# Altium schematic sheet v2.SchDoc
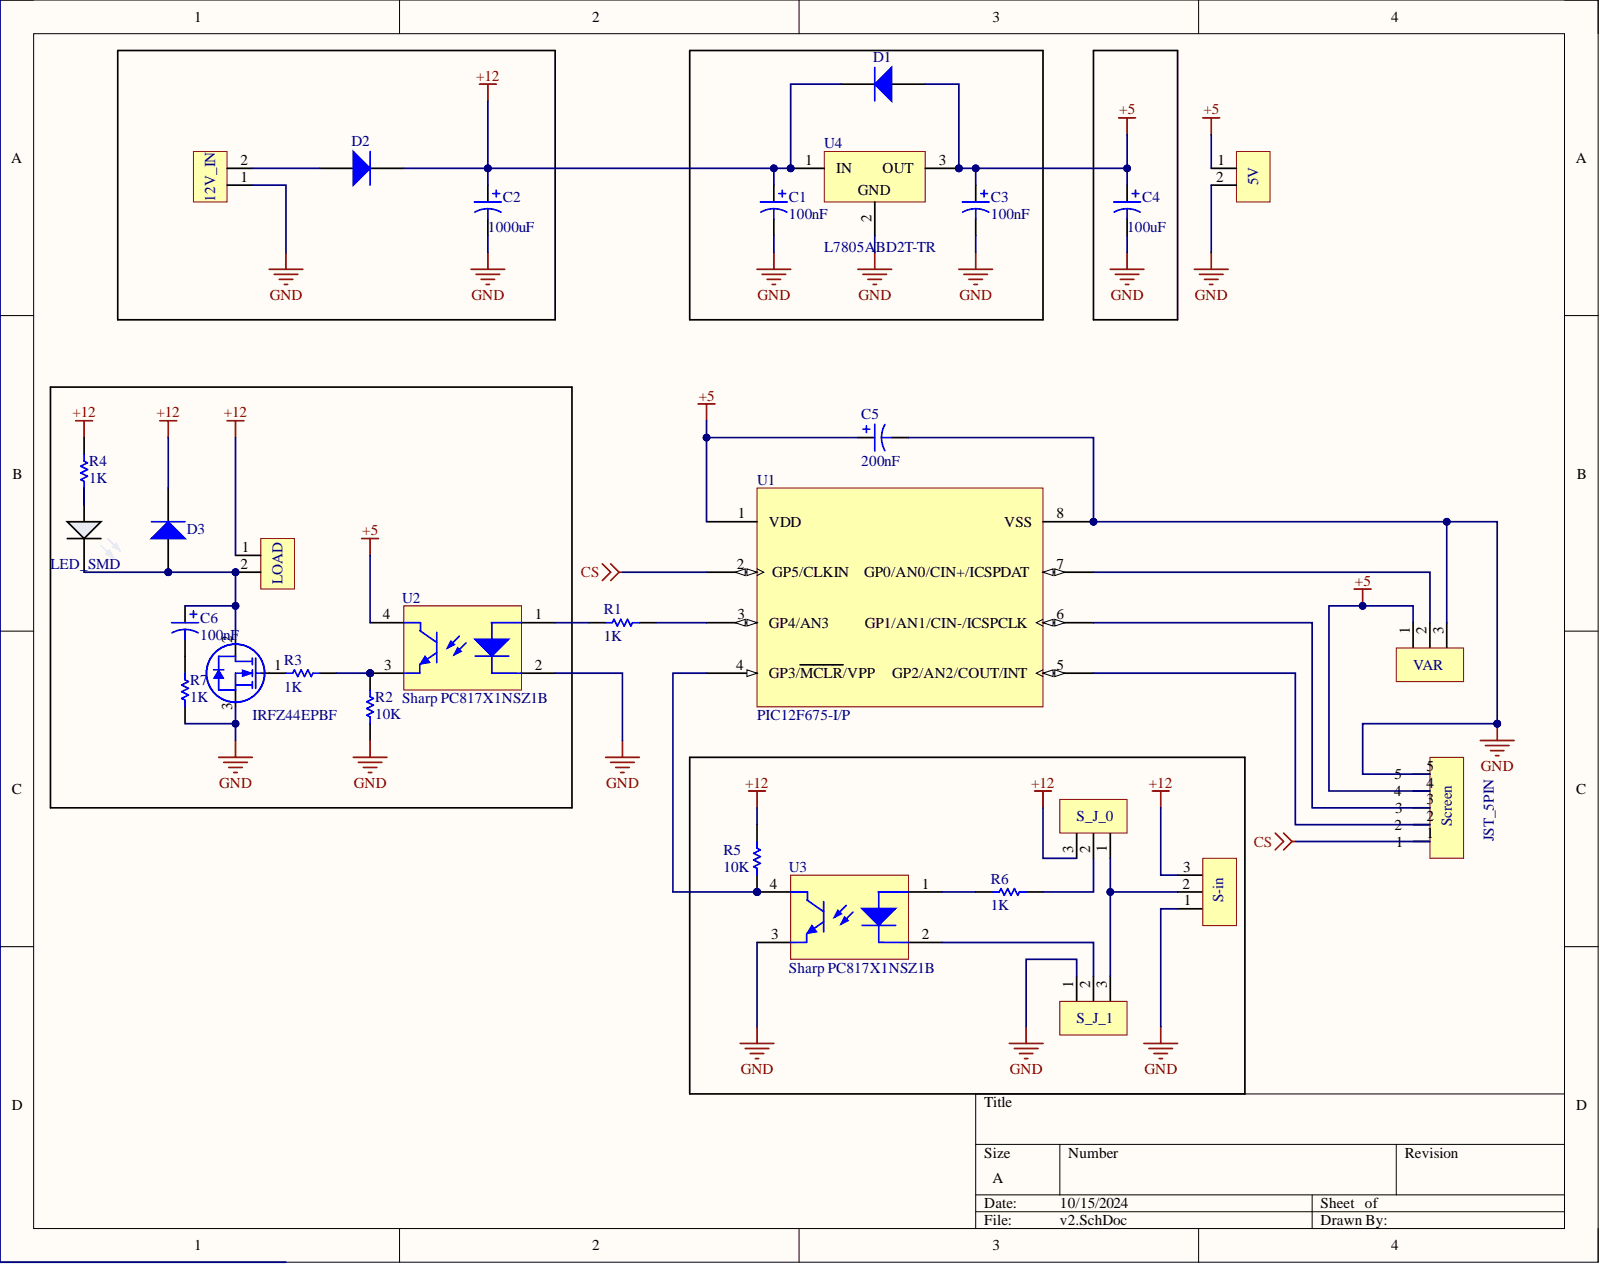
[diagram p0: v2.SchDoc]

## Parts
5V  5V  [New footprint 1]
12_V_IN  12V_IN  [New footprint 1]
ASD  LED_SMD  [PCB-dyudieb3kox3j5j1lvp2-1]
C1  100nF  [PCB-imxzc0h3ulzopa7zwnih-1]
C2  1000uF  [PCB-1t31qovrtxaf06xpvrkf-1]
C3  100nF  [PCB-imxzc0h3ulzopa7zwnih-1]
C4  100uF  [PCB-1t31qovrtxaf06xpvrkf-1]
C5  200nF  [PCB-imxzc0h3ulzopa7zwnih-1]
C6  100nF  [PCB-imxzc0h3ulzopa7zwnih-1]
D1  Diode 1N4007 SMD v3  [PCB-e80c224be0e437cb-1]  (value not drawn)
D2  Diode 1N4007 SMD v3  [PCB-e80c224be0e437cb-1]  (value not drawn)
D3  Diode 1N4007 SMD v3  [PCB-e80c224be0e437cb-1]  (value not drawn)
LOAD  LOAD  [New footprint 1]
Q1  IRFZ44EPBF  [PCB-ak5q15cuqknzy1h42gr5-1]
R1  1K  [RESC2013X60X35NL10T20]
R2  10K  [RESC2013X60X35NL10T20]
R3  1K  [RESC2013X60X35NL10T20]
R4  1K  [RESC2013X60X35NL10T20]
R5  10K  [RESC2013X60X35NL10T20]
R6  1K  [RESC2013X60X35NL10T20]
R7  1K  [RESC2013X60X35NL10T20]
S-in  3 PADS WIRE SOLDER  [New footprint 1]  (value not drawn)
S_J_0  3 Pad solder jumper  [New footprint 1]  (value not drawn)
S_J_1  jumper  [New footprint 1]  (value not drawn)
Screen  JST_5PIN  [New footprint 1]
U1  PIC12F675-I/P  [PDIP300-P8]
U2  Sharp PC817X1NSZ1B  Manufacturer Part Number: PC817X1NSZ1B  [PCB-l0nr7q0h3j53k7qqs7uk-1]
U3  Sharp PC817X1NSZ1B  Manufacturer Part Number: PC817X1NSZ1B  [PCB-l0nr7q0h3j53k7qqs7uk-1]
U4  L7805ABD2T-TR  [PCB-yc928awisvvy437doe32-1]
VAR  VAR  [New footprint 1]

## Nets
+5: 5V.1 C3.1 C4.1 C5.1 D1.1 Screen.4 U1.1(VDD) U2.4 U4.3 VAR.1
+12: C1.1 C2.1 D1.2 D2.2 D3.2 LOAD.1 R4.2 R5.2 S-in.3 S_J_0.3 U4.1
CS: Screen.1 U1.2(GP5/CLKIN)
GND: 5V.2 12_V_IN.1 C1.2 C2.2 C3.2 C4.2 C5.2 Q1.3 R2.1 R7.1 S-in.1 S_J_1.1 Screen.5 U1.8(VSS) U2.2 U3.3 U4.2 VAR.3
Net12_V_IN_2: 12_V_IN.2 D2.1
NetASD_1: ASD.1 R4.1
NetASD_2: ASD.2 C6.1 D3.1 LOAD.2 Q1.2
NetC6_2: C6.2 R7.2
NetQ1_1: Q1.1 R3.1
NetR1_1: R1.1 U2.1
NetR1_2: R1.2 U1.3(GP4/AN3)
NetR2_2: R2.2 R3.2 U2.3
NetR5_1: R5.1 U1.4(GP3/MCLR/VPP) U3.4
NetR6_1: R6.1 U3.1
NetR6_2: R6.2 S_J_0.2
NetS-in_2: S-in.2 S_J_0.1 S_J_1.3
NetS_J_1_2: S_J_1.2 U3.2
NetScreen_2: Screen.2 U1.5(GP2/AN2/COUT/INT)
NetScreen_3: Screen.3 U1.6(GP1/AN1/CIN-/ICSPCLK)
NetU1_7: U1.7(GP0/AN0/CIN+/ICSPDAT) VAR.2

## Library data of the parts
5V 12_V_IN LOAD = CMP-3vb98750j0ckkpryn50s-2: 2mm * 4 mm , 2mm clearance; Component Kind: Standard; CustomComponentID: 3vb98750j0ckkpryn50s; Library Name: My Personal Space
ASD = CMP-0283cbd1fd8add44-10: High CRI LED; Case/Package: 5730; Color: 2700K; Component Kind: Standard; Library Name: My Personal Space; Number of Pins: 2; Octopart URL: https://cdn.shopify.com/s/files/1/0344/6401/files/YJWJ005-1.1_YJ-VTC-5730-G01.pdf?7088009637272241372; OctopartID: 0283cbd1fd8add44; Size-Height: 0.80mm; Size-Length: 6.80mm; Size-Width: 3.20mm
C1 C3 C5 C6 = CMP-6a29a3234e15b5ee-3: Capacitor Tantalum Solid 10uF 25V 10% (5.5 X 9mm) Radial 2.5mm 2.5 Ohm 125C Bulk; Manufacturer: AVX; Manufacturer Part Number: TAP106K025SCS; Capacitance: 10.0 µF; Equivalent Series Resistance (ESR): 2.50 Ω; Lead-Free Status: Lead Free; Voltage Rating (DC): 25.0 V; Capacitance Tolerance: ±10%; Component Kind: Standard; Library Name: My Personal Space; Mounting Style: Through Hole; Number of Pins: 2; Octopart URL: http://octopart.com/tap106k025scs-avx-346; OctopartID: 6a29a3234e15b5ee; Packaging: Bag; REACH SVHC Compliance: No SVHC; RoHS: Compliant; Size-Diameter: 5.59 mm; Size-Height: 8.89 mm
C2 C4 = CMP-14a1dc692a51cb66-8: Cap Aluminum Polymer 100uF 16VDC 20% (6.3 X 6mm) Radial 2.5mm 0.024 Ohm 2490mA 5000h 105C T/R; Manufacturer Part Number: 16SEPC100M+TSS; Capacitance: 100 µF; Equivalent Series Resistance (ESR): 24.0 mΩ; Lead-Free Status: Lead Free; Voltage Rating (DC): 16.0 V; Capacitance Tolerance: ±20%; Component Kind: Standard; Library Name: My Personal Space; Lifecycle Status: Not Listed by Manufacturer; Mounting Style: Radial; Octopart URL: https://octopart.com/16sepc100m%2Btss-panasonic-29498262; OctopartID: 14a1dc692a51cb66; Operating Temperature: 105 °C (max); Packaging: Reel; RoHS: Compliant
D1-D3 = CMP-815216-18: Diode Standard Recovery Rectifier; Manufacturer Part Number: 1N4007-T; Capacitance: 8.00 pF; Current Rating: 1.00 A; Lead-Free Status: Exempt; Output Current: 1.00 A (max); Voltage Rating (DC): 1.00 kV; Case/Package: DO-41; Component Kind: Standard; Library Name: My Personal Space; Lifecycle Status: Active; Mounting Style: Through Hole; Number of Pins: 2; Octopart URL: http://retam.co.rs/katalog#RGlvZGE=:aXNwcmF2bGphxI1rYQ==/; OctopartID: e80c224be0e437cb; Operating Temperature: -65.0 °C to 150 °C; Packaging: Tape & Reel (TR), Cut Tape (CT); Polarity: Standard; REACH SVHC Compliance: No SVHC; RoHS: Compliant
Q1 = CMP-b4407ea7b8c26b87-1: MOSFET, Power; N-Ch; VDSS 60V; RDS(ON) 0.023Ohm; ID 48A; TO-220AB; PD 110W; VGS +/-20V; Breakdown Voltage (Drain to Source): 60.0 V; Continuous Drain Current (Ids): 48.0 A; Gate Charge: 60.0 nC; Input Capacitance: 1.36 nF; Lead-Free Status: Lead Free; Polarity: N-Channel; Rise Time: 60.0 ns; Component Kind: Standard; Current Rating: 48.0 A; Drain to Source Voltage (Vds): 60.0 V; Library Name: My Personal Space; Lifecycle Status: Active; Manufacturer Part Number: IRFZ44EPBF; Mounting Style: Through Hole; Number of Pins: 3; Octopart URL: https://octopart.com/irfz44epbf-infineon-65874404; OctopartID: b4407ea7b8c26b87; Operating Temperature: -55.0 °C to 175 °C; Packaging: Bulk; Part Family: IRFZ44E; Power Dissipation: 110 W; REACH SVHC Compliance: No SVHC; RoHS: Compliant; Voltage Rating (DC): 60.0 V
R1-R7 = CMP-1854012-5: 4.99 kOhms ±1% 0.5W, 1/2W Chip Resistor 0805 (2012 Metric) Automotive AEC-Q200, Pulse Withstanding Thick Film; Manufacturer Part Number: CRCW08054K99FKEAHP; Case/Package (SI): 2012; Lead-Free Status: Lead Free; Power Rating: 0.125 W; Resistance: 4.99k; Resistance Tolerance: ±5%; Voltage Rating (DC): 150.0 V; Case/Package: 0805; Component Kind: Standard; Library Name: My Personal Space; Mounting Style: Surface Mount; Number of Pins: 2; Octopart Url: https://octopart.com/rc0805jr-072r2l-yageo-1223392; OctopartID: 7e7db765f1fba1ba; Packaging: Cut Tape (CT); RoHS: Compliant; Size-Height: 0.0005 m; Size-Length: 0.002 m; Size-Width: 0.00125 m
S-in VAR = CMP-06qaa1fqar8lrlinfxbs-1: 4mm * 2mm , 2mm clearance; Component Kind: Standard; CustomComponentID: 06qaa1fqar8lrlinfxbs; Library Name: My Personal Space
S_J_0 S_J_1 = CMP-5f07wc33gd11id5zq4rf-1: 1.5mm * 1.5mm , clearance 1mm; Component Kind: Standard; CustomComponentID: 5f07wc33gd11id5zq4rf; Library Name: My Personal Space
Screen = CMP-sq9xue0d8wjqteo6dse1-2: Component Kind: Standard; CustomComponentID: sq9xue0d8wjqteo6dse1; Library Name: My Personal Space
U1 = CMP-1155266-6: FLASH-Based 8-Bit CMOS Microcontroller, 10-Bit A/D, 8-Pin PDIP, Industrial Temperature; Access Time: 2e-05 s; Clock Speed: 20000000.0 Hz; Core Architecture: PIC; Core Sub-Architecture: PIC12; FLASH Memory Size: 1792 B; Lead-Free Status: Lead Free; Number of Bits: 8; Number of I/O Pins: 6; RAM Memory Size: 64 B; Supply Voltage (DC): 5.0 V; Case/Package: DIP; Component Kind: Standard; Library Name: My Personal Space; Lifecycle Status: Active; Manufacturer Part Number: PIC12F675-I/P; Mounting Style: Through Hole; Number of Pins: 8; Octopart Url: https://octopart.com/pic12f675-i%2Fp-microchip-116186; OctopartID: 7bdeb2d59b62a419; Packaging: Bulk; REACH SVHC Compliance: No SVHC; RoHS: Compliant
U2 U3 = CMP-1f4cd2986cffc08b-1: Optocoupler; THT; Channels: 1; Out: transistor; Uinsul: 5kV; Uce: 80V; Component Kind: Standard; Library Name: My Personal Space; Mounting Style: Through Hole; Octopart URL: https://octopart.com/pc817x1nsz1b-sharp-81680085; OctopartID: 1f4cd2986cffc08b
U4 = CMP-1170709-5: Positive Voltage Regulator, 3-Pin D2PAK, Tape and Reel; Dropout Voltage: 2.0 V; Lead-Free Status: Lead Free; Number of Outputs: 1; Component Kind: Standard; Library Name: My Personal Space; Lifecycle Status: Active; Manufacturer Part Number: L7805ABD2T-TR; Mounting Style: Surface Mount; Number of Pins: 3; Octopart Url: https://octopart.com/l7805abd2t-tr-stmicroelectronics-526655; OctopartID: dcae15acf0f70369; REACH SVHC Compliance: No SVHC; RoHS: Compliant
